# Altium schematic sheet FPGA.SchDoc(FPGA)
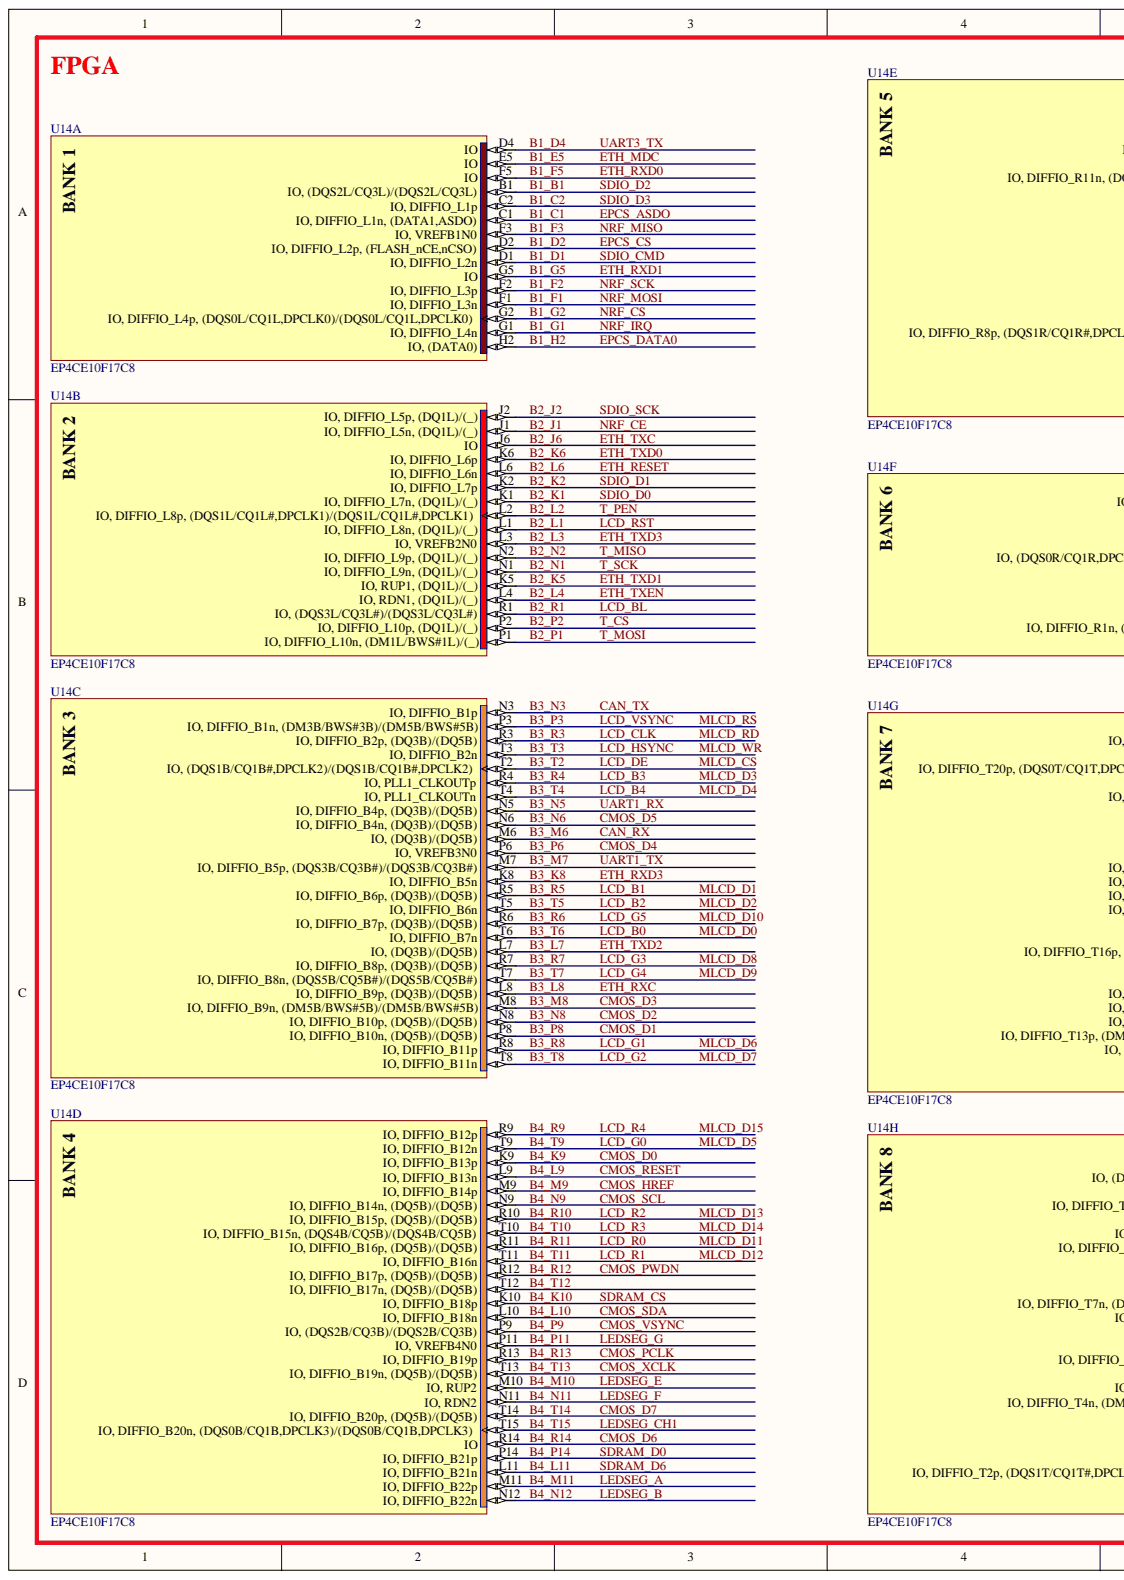
[diagram p0: FPGA.SchDoc, left]
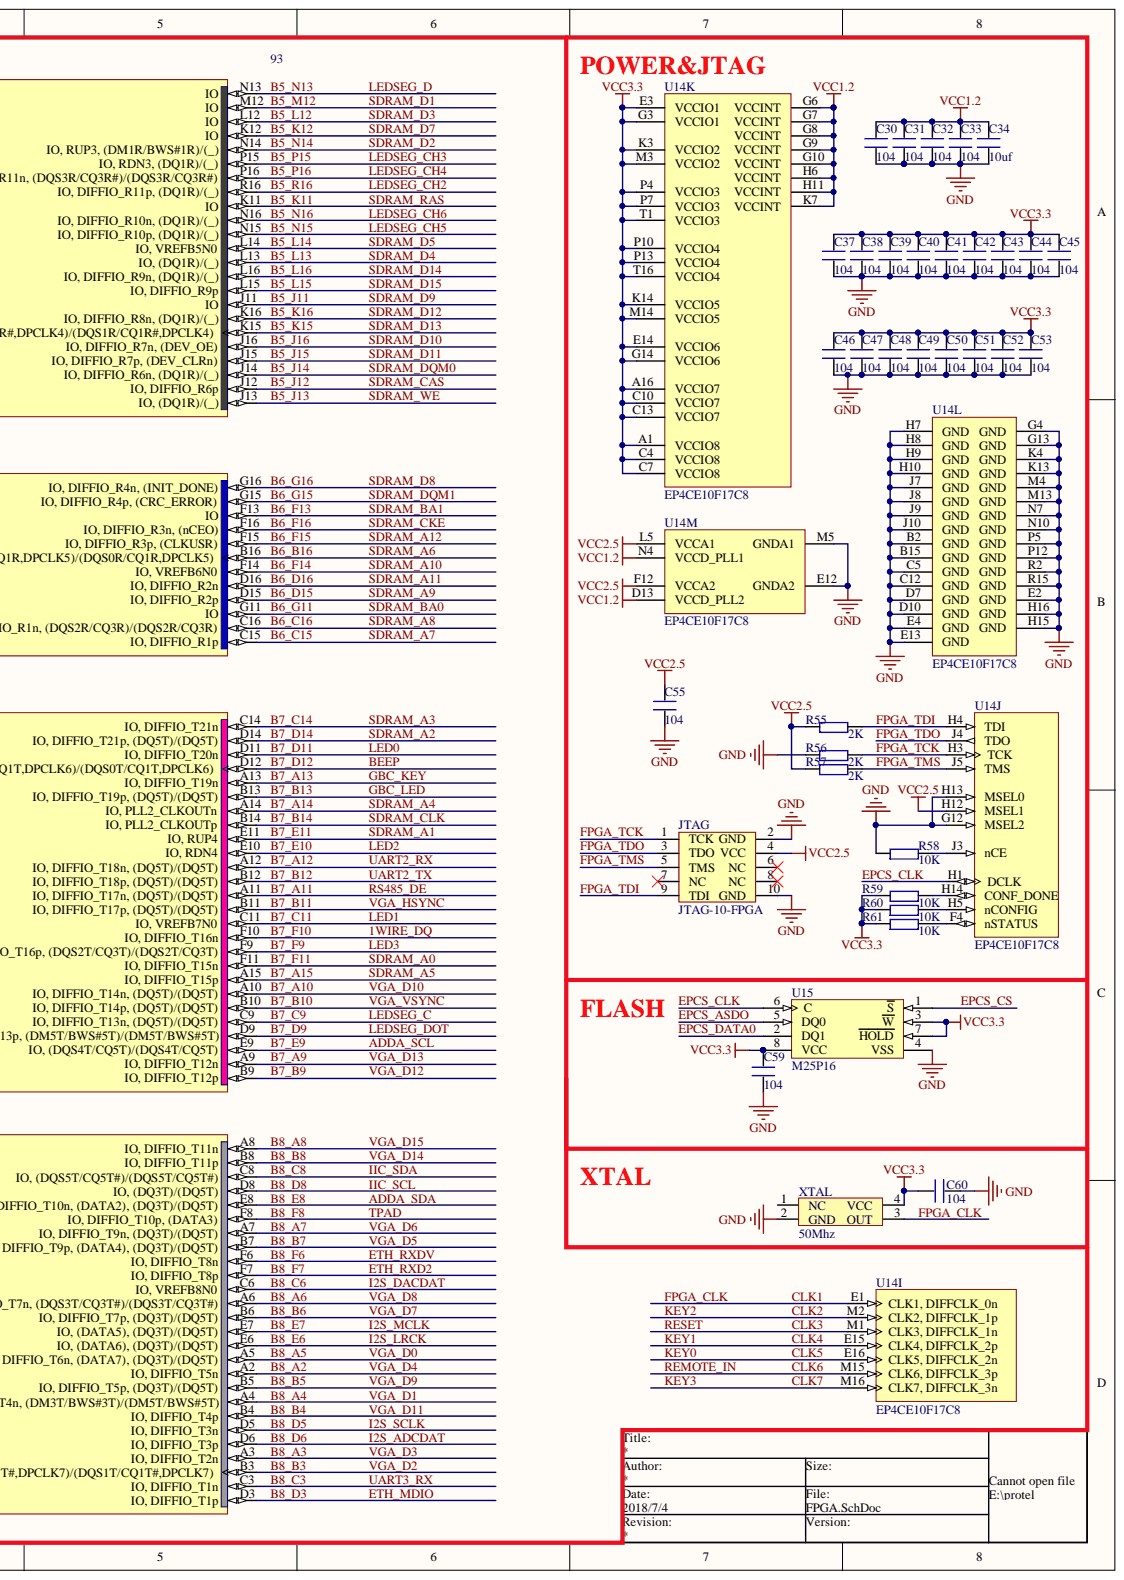
[diagram p1: FPGA.SchDoc, right]

## Parts
C30  104  [0402]
C31  104  [0402]
C32  104  [0402]
C33  104  [0402]
C34  10uf  [0805_SMALL]
C37  104  [0402]
C38  104  [0402]
C39  104  [0402]
C40  104  [0402]
C41  104  [0402]
C42  104  [0402]
C43  104  [0402]
C44  104  [0402]
C45  104  [0402]
C46  104  [0402]
C47  104  [0402]
C48  104  [0402]
C49  104  [0402]
C50  104  [0402]
C51  104  [0402]
C52  104  [0402]
C53  104  [0402]
C55  104  [0402]
C59  104  [0603P]
C60  104  [0603P]
JTAG  JTAG-10-FPGA  [JTAG_10_2.54]
R55  2K  [0603P]
R56  2K  [0603P]
R57  2K  [0603P]
R58  10K  [0402]
R59  10K  [0402]
R60  10K  [0402]
R61  10K  [0402]
U14  EP4CE10F17C8  [FBGA256]  (parts A,B,C,D,E,F,G,H,I,J,K,L,M)
U15  M25P16  [sop-8]
XTAL  50Mhz  [XTAL3225]

## Nets
GND: C30.1 C31.1 C32.1 C33.1 C34.1 C37.1 C38.1 C39.1 C40.1 C41.1 C42.1 C43.1 C44.1 C45.1 C46.1 C47.1 C48.1 C49.1 C50.1 C51.1 C52.1 C53.1 C55.1 C59.1 C60.1 JTAG.2(GND) JTAG.10(GND) R56.1 R58.1 U14.B2(GND) U14.B15(GND) U14.C5(GND) U14.C12(GND) U14.D7(GND) U14.D10(GND) U14.E2(GND) U14.E4(GND) U14.E12(GNDA2) U14.E13(GND) U14.G4(GND) U14.G12(MSEL2) U14.G13(GND) U14.H7(GND) U14.H8(GND) U14.H9(GND) U14.H10(GND) U14.H13(MSEL0) U14.H15(GND) U14.H16(GND) U14.J7(GND) U14.J8(GND) U14.J9(GND) U14.J10(GND) U14.K4(GND) U14.K13(GND) U14.M4(GND) U14.M5(GNDA1) U14.M13(GND) U14.N7(GND) U14.N10(GND) U14.P5(GND) U14.P12(GND) U14.R2(GND) U14.R15(GND) U15.4(VSS) XTAL.2(GND)
VCC1.2: C30.2 C31.2 C32.2 C33.2 C34.2 U14.D13(VCCD_PLL2) U14.G6(VCCINT) U14.G7(VCCINT) U14.G8(VCCINT) U14.G9(VCCINT) U14.G10(VCCINT) U14.H6(VCCINT) U14.H11(VCCINT) U14.K7(VCCINT) U14.N4(VCCD_PLL1)
VCC2.5: C55.2 JTAG.4(VCC) R55.1 R57.1 U14.F12(VCCA2) U14.H12(MSEL1) U14.L5(VCCA1)
VCC3.3: C37.2 C38.2 C39.2 C40.2 C41.2 C42.2 C43.2 C44.2 C45.2 C46.2 C47.2 C48.2 C49.2 C50.2 C51.2 C52.2 C53.2 C59.2 C60.2 R59.1 R60.1 R61.1 U14.A1(VCCIO8) U14.A16(VCCIO7) U14.C4(VCCIO8) U14.C7(VCCIO8) U14.C10(VCCIO7) U14.C13(VCCIO7) U14.E3(VCCIO1) U14.E14(VCCIO6) U14.G3(VCCIO1) U14.G14(VCCIO6) U14.K3(VCCIO2) U14.K14(VCCIO5) U14.M3(VCCIO2) U14.M14(VCCIO5) U14.P4(VCCIO3) U14.P7(VCCIO3) U14.P10(VCCIO4) U14.P13(VCCIO4) U14.T1(VCCIO3) U14.T16(VCCIO4) U15.3(W) U15.7(HOLD) U15.8(VCC) XTAL.4(VCC)
1WIRE_DQ: U14.F10(IO,_DIFFIO_T16n)
ADDA_SCL: U14.E9(IO,_(DQS4T/CQ5T)/(DQS4T/CQ5T))
ADDA_SDA: U14.E8(IO,_DIFFIO_T10n,_(DATA2),_(DQ3T)/(DQ5T))
B1_B1: U14.B1(IO,_(DQS2L/CQ3L)/(DQS2L/CQ3L))
B1_C1: U14.C1(IO,_DIFFIO_L1n,_(DATA1,ASDO)) U15.5(DQ0)
B1_C2: U14.C2(IO,_DIFFIO_L1p)
B1_D1: U14.D1(IO,_DIFFIO_L2n)
B1_D2: U14.D2(IO,_DIFFIO_L2p,_(FLASH_nCE,nCSO)) U15.1(S)
B1_D4: U14.D4(IO)
B1_E5: U14.E5(IO)
B1_F1: U14.F1(IO,_DIFFIO_L3n)
B1_F2: U14.F2(IO,_DIFFIO_L3p)
B1_F3: U14.F3(IO,_VREFB1N0)
B1_F5: U14.F5(IO)
B1_G1: U14.G1(IO,_DIFFIO_L4n)
B1_G2: U14.G2(IO,_DIFFIO_L4p,_(DQS0L/CQ1L,DPCLK0)/(DQS0L/CQ1L,DPCLK0))
B1_G5: U14.G5(IO)
B1_H2: U14.H2(IO,_(DATA0)) U15.2(DQ1)
B2_J1: U14.J1(IO,_DIFFIO_L5n,_(DQ1L)/(_))
B2_J2: U14.J2(IO,_DIFFIO_L5p,_(DQ1L)/(_))
B2_J6: U14.J6(IO)
B2_K1: U14.K1(IO,_DIFFIO_L7n,_(DQ1L)/(_))
B2_K2: U14.K2(IO,_DIFFIO_L7p)
B2_K5: U14.K5(IO,_RUP1,_(DQ1L)/(_))
B2_K6: U14.K6(IO,_DIFFIO_L6p)
B2_L1: U14.L1(IO,_DIFFIO_L8n,_(DQ1L)/(_))
B2_L2: U14.L2(IO,_DIFFIO_L8p,_(DQS1L/CQ1L#,DPCLK1)/(DQS1L/CQ1L#,DPCLK1))
B2_L3: U14.L3(IO,_VREFB2N0)
B2_L4: U14.L4(IO,_RDN1,_(DQ1L)/(_))
B2_L6: U14.L6(IO,_DIFFIO_L6n)
B2_N1: U14.N1(IO,_DIFFIO_L9n,_(DQ1L)/(_))
B2_N2: U14.N2(IO,_DIFFIO_L9p,_(DQ1L)/(_))
B2_P1: U14.P1(IO,_DIFFIO_L10n,_(DM1L/BWS#1L)/(_))
B2_P2: U14.P2(IO,_DIFFIO_L10p,_(DQ1L)/(_))
B2_R1: U14.R1(IO,_(DQS3L/CQ3L#)/(DQS3L/CQ3L#))
B3_K8: U14.K8(IO,_DIFFIO_B5n)
B3_L7: U14.L7(IO,_(DQ3B)/(DQ5B))
B3_L8: U14.L8(IO,_DIFFIO_B9p,_(DQ3B)/(DQ5B))
B3_M6: U14.M6(IO,_(DQ3B)/(DQ5B))
B3_M7: U14.M7(IO,_DIFFIO_B5p,_(DQS3B/CQ3B#)/(DQS3B/CQ3B#))
B3_M8: U14.M8(IO,_DIFFIO_B9n,_(DM5B/BWS#5B)/(DM5B/BWS#5B))
B3_N3: U14.N3(IO,_DIFFIO_B1p)
B3_N5: U14.N5(IO,_DIFFIO_B4p,_(DQ3B)/(DQ5B))
B3_N6: U14.N6(IO,_DIFFIO_B4n,_(DQ3B)/(DQ5B))
B3_N8: U14.N8(IO,_DIFFIO_B10p,_(DQ5B)/(DQ5B))
B3_P3: U14.P3(IO,_DIFFIO_B1n,_(DM3B/BWS#3B)/(DM5B/BWS#5B))
B3_P6: U14.P6(IO,_VREFB3N0)
B3_P8: U14.P8(IO,_DIFFIO_B10n,_(DQ5B)/(DQ5B))
B3_R3: U14.R3(IO,_DIFFIO_B2p,_(DQ3B)/(DQ5B))
B3_R4: U14.R4(IO,_PLL1_CLKOUTp)
B3_R5: U14.R5(IO,_DIFFIO_B6p,_(DQ3B)/(DQ5B))
B3_R6: U14.R6(IO,_DIFFIO_B7p,_(DQ3B)/(DQ5B))
B3_R7: U14.R7(IO,_DIFFIO_B8p,_(DQ3B)/(DQ5B))
B3_R8: U14.R8(IO,_DIFFIO_B11p)
B3_T2: U14.T2(IO,_(DQS1B/CQ1B#,DPCLK2)/(DQS1B/CQ1B#,DPCLK2))
B3_T3: U14.T3(IO,_DIFFIO_B2n)
B3_T4: U14.T4(IO,_PLL1_CLKOUTn)
B3_T5: U14.T5(IO,_DIFFIO_B6n)
B3_T6: U14.T6(IO,_DIFFIO_B7n)
B3_T7: U14.T7(IO,_DIFFIO_B8n,_(DQS5B/CQ5B#)/(DQS5B/CQ5B#))
B3_T8: U14.T8(IO,_DIFFIO_B11n)
B4_K9: U14.K9(IO,_DIFFIO_B13p)
B4_K10: U14.K10(IO,_DIFFIO_B18p)
B4_L9: U14.L9(IO,_DIFFIO_B13n)
B4_L10: U14.L10(IO,_DIFFIO_B18n)
B4_L11: U14.L11(IO,_DIFFIO_B21n)
B4_M9: U14.M9(IO,_DIFFIO_B14p)
B4_M10: U14.M10(IO,_RUP2)
B4_M11: U14.M11(IO,_DIFFIO_B22p)
B4_N9: U14.N9(IO,_DIFFIO_B14n,_(DQ5B)/(DQ5B))
B4_N11: U14.N11(IO,_RDN2)
B4_N12: U14.N12(IO,_DIFFIO_B22n)
B4_P9: U14.P9(IO,_(DQS2B/CQ3B)/(DQS2B/CQ3B))
B4_P11: U14.P11(IO,_VREFB4N0)
B4_P14: U14.P14(IO,_DIFFIO_B21p)
B4_R9: U14.R9(IO,_DIFFIO_B12p)
B4_R10: U14.R10(IO,_DIFFIO_B15p,_(DQ5B)/(DQ5B))
B4_R11: U14.R11(IO,_DIFFIO_B16p,_(DQ5B)/(DQ5B))
B4_R12: U14.R12(IO,_DIFFIO_B17p,_(DQ5B)/(DQ5B))
B4_R13: U14.R13(IO,_DIFFIO_B19p)
B4_R14: U14.R14(IO)
B4_T9: U14.T9(IO,_DIFFIO_B12n)
B4_T10: U14.T10(IO,_DIFFIO_B15n,_(DQS4B/CQ5B)/(DQS4B/CQ5B))
B4_T11: U14.T11(IO,_DIFFIO_B16n)
B4_T12: U14.T12(IO,_DIFFIO_B17n,_(DQ5B)/(DQ5B))
B4_T13: U14.T13(IO,_DIFFIO_B19n,_(DQ5B)/(DQ5B))
B4_T14: U14.T14(IO,_DIFFIO_B20p,_(DQ5B)/(DQ5B))
B4_T15: U14.T15(IO,_DIFFIO_B20n,_(DQS0B/CQ1B,DPCLK3)/(DQS0B/CQ1B,DPCLK3))
B5_J11: U14.J11(IO)
B5_J12: U14.J12(IO,_DIFFIO_R6p)
B5_J13: U14.J13(IO,_(DQ1R)/(_))
B5_J14: U14.J14(IO,_DIFFIO_R6n,_(DQ1R)/(_))
B5_J15: U14.J15(IO,_DIFFIO_R7p,_(DEV_CLRn))
B5_J16: U14.J16(IO,_DIFFIO_R7n,_(DEV_OE))
B5_K11: U14.K11(IO)
B5_K12: U14.K12(IO)
B5_K15: U14.K15(IO,_DIFFIO_R8p,_(DQS1R/CQ1R#,DPCLK4)/(DQS1R/CQ1R#,DPCLK4))
B5_K16: U14.K16(IO,_DIFFIO_R8n,_(DQ1R)/(_))
B5_L12: U14.L12(IO)
B5_L13: U14.L13(IO,_(DQ1R)/(_))
B5_L14: U14.L14(IO,_VREFB5N0)
B5_L15: U14.L15(IO,_DIFFIO_R9p)
B5_L16: U14.L16(IO,_DIFFIO_R9n,_(DQ1R)/(_))
B5_M12: U14.M12(IO)
B5_N13: U14.N13(IO)
B5_N14: U14.N14(IO,_RUP3,_(DM1R/BWS#1R)/(_))
B5_N15: U14.N15(IO,_DIFFIO_R10p,_(DQ1R)/(_))
B5_N16: U14.N16(IO,_DIFFIO_R10n,_(DQ1R)/(_))
B5_P15: U14.P15(IO,_RDN3,_(DQ1R)/(_))
B5_P16: U14.P16(IO,_DIFFIO_R11n,_(DQS3R/CQ3R#)/(DQS3R/CQ3R#))
B5_R16: U14.R16(IO,_DIFFIO_R11p,_(DQ1R)/(_))
B6_B16: U14.B16(IO,_(DQS0R/CQ1R,DPCLK5)/(DQS0R/CQ1R,DPCLK5))
B6_C15: U14.C15(IO,_DIFFIO_R1p)
B6_C16: U14.C16(IO,_DIFFIO_R1n,_(DQS2R/CQ3R)/(DQS2R/CQ3R))
B6_D15: U14.D15(IO,_DIFFIO_R2p)
B6_D16: U14.D16(IO,_DIFFIO_R2n)
B6_F13: U14.F13(IO)
B6_F14: U14.F14(IO,_VREFB6N0)
B6_F15: U14.F15(IO,_DIFFIO_R3p,_(CLKUSR))
B6_F16: U14.F16(IO,_DIFFIO_R3n,_(nCEO))
B6_G11: U14.G11(IO)
B6_G15: U14.G15(IO,_DIFFIO_R4p,_(CRC_ERROR))
B6_G16: U14.G16(IO,_DIFFIO_R4n,_(INIT_DONE))
B7_A9: U14.A9(IO,_DIFFIO_T12n)
B7_A10: U14.A10(IO,_DIFFIO_T14n,_(DQ5T)/(DQ5T))
B7_A11: U14.A11(IO,_DIFFIO_T17n,_(DQ5T)/(DQ5T))
B7_A12: U14.A12(IO,_DIFFIO_T18n,_(DQ5T)/(DQ5T))
B7_A13: U14.A13(IO,_DIFFIO_T19n)
B7_A14: U14.A14(IO,_PLL2_CLKOUTn)
B7_A15: U14.A15(IO,_DIFFIO_T15p)
B7_B9: U14.B9(IO,_DIFFIO_T12p)
B7_B10: U14.B10(IO,_DIFFIO_T14p,_(DQ5T)/(DQ5T))
B7_B11: U14.B11(IO,_DIFFIO_T17p,_(DQ5T)/(DQ5T))
B7_B12: U14.B12(IO,_DIFFIO_T18p,_(DQ5T)/(DQ5T))
B7_B13: U14.B13(IO,_DIFFIO_T19p,_(DQ5T)/(DQ5T))
B7_B14: U14.B14(IO,_PLL2_CLKOUTp)
B7_C9: U14.C9(IO,_DIFFIO_T13n,_(DQ5T)/(DQ5T))
B7_C11: U14.C11(IO,_VREFB7N0)
B7_C14: U14.C14(IO,_DIFFIO_T21n)
B7_D9: U14.D9(IO,_DIFFIO_T13p,_(DM5T/BWS#5T)/(DM5T/BWS#5T))
B7_D11: U14.D11(IO,_DIFFIO_T20n)
B7_D12: U14.D12(IO,_DIFFIO_T20p,_(DQS0T/CQ1T,DPCLK6)/(DQS0T/CQ1T,DPCLK6))
B7_D14: U14.D14(IO,_DIFFIO_T21p,_(DQ5T)/(DQ5T))
B7_E10: U14.E10(IO,_RDN4)
B7_E11: U14.E11(IO,_RUP4)
B7_F9: U14.F9(IO,_DIFFIO_T16p,_(DQS2T/CQ3T)/(DQS2T/CQ3T))
B7_F11: U14.F11(IO,_DIFFIO_T15n)
B8_A2: U14.A2(IO,_DIFFIO_T5n)
B8_A3: U14.A3(IO,_DIFFIO_T2n)
B8_A4: U14.A4(IO,_DIFFIO_T4n,_(DM3T/BWS#3T)/(DM5T/BWS#5T))
B8_A5: U14.A5(IO,_DIFFIO_T6n,_(DATA7),_(DQ3T)/(DQ5T))
B8_A6: U14.A6(IO,_DIFFIO_T7n,_(DQS3T/CQ3T#)/(DQS3T/CQ3T#))
B8_A7: U14.A7(IO,_DIFFIO_T9n,_(DQ3T)/(DQ5T))
B8_A8: U14.A8(IO,_DIFFIO_T11n)
B8_B3: U14.B3(IO,_DIFFIO_T2p,_(DQS1T/CQ1T#,DPCLK7)/(DQS1T/CQ1T#,DPCLK7))
B8_B4: U14.B4(IO,_DIFFIO_T4p)
B8_B5: U14.B5(IO,_DIFFIO_T5p,_(DQ3T)/(DQ5T))
B8_B6: U14.B6(IO,_DIFFIO_T7p,_(DQ3T)/(DQ5T))
B8_B7: U14.B7(IO,_DIFFIO_T9p,_(DATA4),_(DQ3T)/(DQ5T))
B8_B8: U14.B8(IO,_DIFFIO_T11p)
B8_C3: U14.C3(IO,_DIFFIO_T1n)
B8_C6: U14.C6(IO,_VREFB8N0)
B8_C8: U14.C8(IO,_(DQS5T/CQ5T#)/(DQS5T/CQ5T#))
B8_D3: U14.D3(IO,_DIFFIO_T1p)
B8_D5: U14.D5(IO,_DIFFIO_T3n)
B8_D6: U14.D6(IO,_DIFFIO_T3p)
B8_D8: U14.D8(IO,_(DQ3T)/(DQ5T))
B8_E6: U14.E6(IO,_(DATA6),_(DQ3T)/(DQ5T))
B8_E7: U14.E7(IO,_(DATA5),_(DQ3T)/(DQ5T))
B8_F6: U14.F6(IO,_DIFFIO_T8n)
B8_F7: U14.F7(IO,_DIFFIO_T8p)
B8_F8: U14.F8(IO,_DIFFIO_T10p,_(DATA3))
CLK1: U14.E1(CLK1,_DIFFCLK_0n) XTAL.3(OUT)
CLK2: U14.M2(CLK2,_DIFFCLK_1p)
CLK3: U14.M1(CLK3,_DIFFCLK_1n)
CLK4: U14.E15(CLK4,_DIFFCLK_2p)
CLK5: U14.E16(CLK5,_DIFFCLK_2n)
CLK6: U14.M15(CLK6,_DIFFCLK_3p)
CLK7: U14.M16(CLK7,_DIFFCLK_3n)
EPCS_CLK: U14.H1(DCLK) U15.6(C)
FPGA_TCK: JTAG.1(TCK) R56.2 U14.H3(TCK)
FPGA_TDI: JTAG.9(TDI) R55.2 U14.H4(TDI)
FPGA_TDO: JTAG.3(TDO) U14.J4(TDO)
FPGA_TMS: JTAG.5(TMS) R57.2 U14.J5(TMS)
NetR58_2: R58.2 U14.J3(nCE)
NetR59_2: R59.2 U14.H14(CONF_DONE)
NetR60_2: R60.2 U14.H5(nCONFIG)
NetR61_2: R61.2 U14.F4(nSTATUS)

Not connected: JTAG 6(NC) 7(NC) 8(NC); XTAL 1(NC)

## Library data of the parts
C30-C34 C37-C53 C55 C59 C60 = C: Library Name: ALIENTEK_COMPONENT.IntLib; Component Kind: Standard
R55-R61 = R: Library Name: ALIENTEK_COMPONENT.IntLib; Component Kind: Standard
U14 = EP4CE6F17C8: Cyclone IV Family FPGA, 2V Core, 179 I/O Pins, 2 PLLs, 256-Pin FBGA, Speed Grade 8, Commercial Grade; Supplier Part Number 1: EP4CE6F17C8-ND; Supplier 1: Digi-Key; Published: 3-May-2010; PackageVersion: ver16.0, Dec-2009; PackageReference: FBGA256; PackageDescription: 256-Ball FineLine Ball Grid Array (FBGA256) - Option 1 - Wire Bond; Library Name: ALIENTEK_COMPONENT.IntLib; DatasheetVersion: ver1.2, Mar-2010; ComponentLink4URL: http://www.altera.com/literature/ds/dspkg.pdf; ComponentLink4Description: Packaging Specification; ComponentLink3URL: http://www.altera.com/literature/lit-dp.jsp?category=cyc%204&showspreadsheet=y; ComponentLink3Description: Pinout Specification; ComponentLink2URL: http://www.altera.com/literature/hb/cyclone-iv/cyclone4-handbook.pdf; ComponentLink2Description: Handbook; ComponentLink1URL: http://www.altera.com/; ComponentLink1Description: Manufacturer Link; Component Kind: Standard; Code_JEDEC: MS-034-AAF-1; Code_IPC: BGA100P16X16-256; Publisher: Altium Limited
U15 = M25P16: 16 Mb (x1) Automotive Serial NOR Flash Memory, 75 MHz, 2.7 to 3.6 V, 8-pin SO8; PartNumber: M25P16-VMN3PB; Part Family: M25P爭ISHIDDEN=T|; Manufacturer: Micron; Voltage: 2.7V-3.6V爭ISHIDDEN=T|; Speed: 75 MHz爭ISHIDDEN=T|; Density: 16Mb爭ISHIDDEN=T|; Width: x1爭ISHIDDEN=T|; Type: Data Storage爭ISHIDDEN=T|; RoHS: Yes爭ISHIDDEN=T|; Part Status: Production爭ISHIDDEN=T|; PackageVersion: Rev 11; PackageReference: MICT-MN-8; PackageDescription: 8-Pin SO Narrow, 3.9 X 4.9 mm, Pitch 1.27 mm; Package: SO8 Narrow爭ISHIDDEN=T|; Mounting Technology: Surface Mount; Library Name: ALIENTEK_COMPONENT.IntLib; DatasheetVersion: Rev. Y; ComponentLink3URL: http://www.micron.com/~/media/Documents/Products/Data%20Sheet/NOR%20Flash/Serial%20NOR/M25P/M25P05A.pdf; ComponentLink3Description: Package Specification; ComponentLink2URL: http://www.micron.com/~/media/Documents/Products/Data%20Sheet/NOR%20Flash/Serial%20NOR/M25P/M25P16.pdf; ComponentLink2Description: Datasheet; ComponentLink1URL: http://www.micron.com/; ComponentLink1Description: Manufacturer URL; Component Kind: Standard; Applications: Automotive爭ISHIDDEN=T|
XTAL = XTAL_S: 有源晶振; Library Name: ALIENTEK_COMPONENT.IntLib; Component Kind: Standard
JTAG = JTAG-10-FPGA: Library Name: ALIENTEK_COMPONENT.IntLib; Component Kind: Standard
